# Altium schematic sheet [06] - Clock_Management.SchDoc
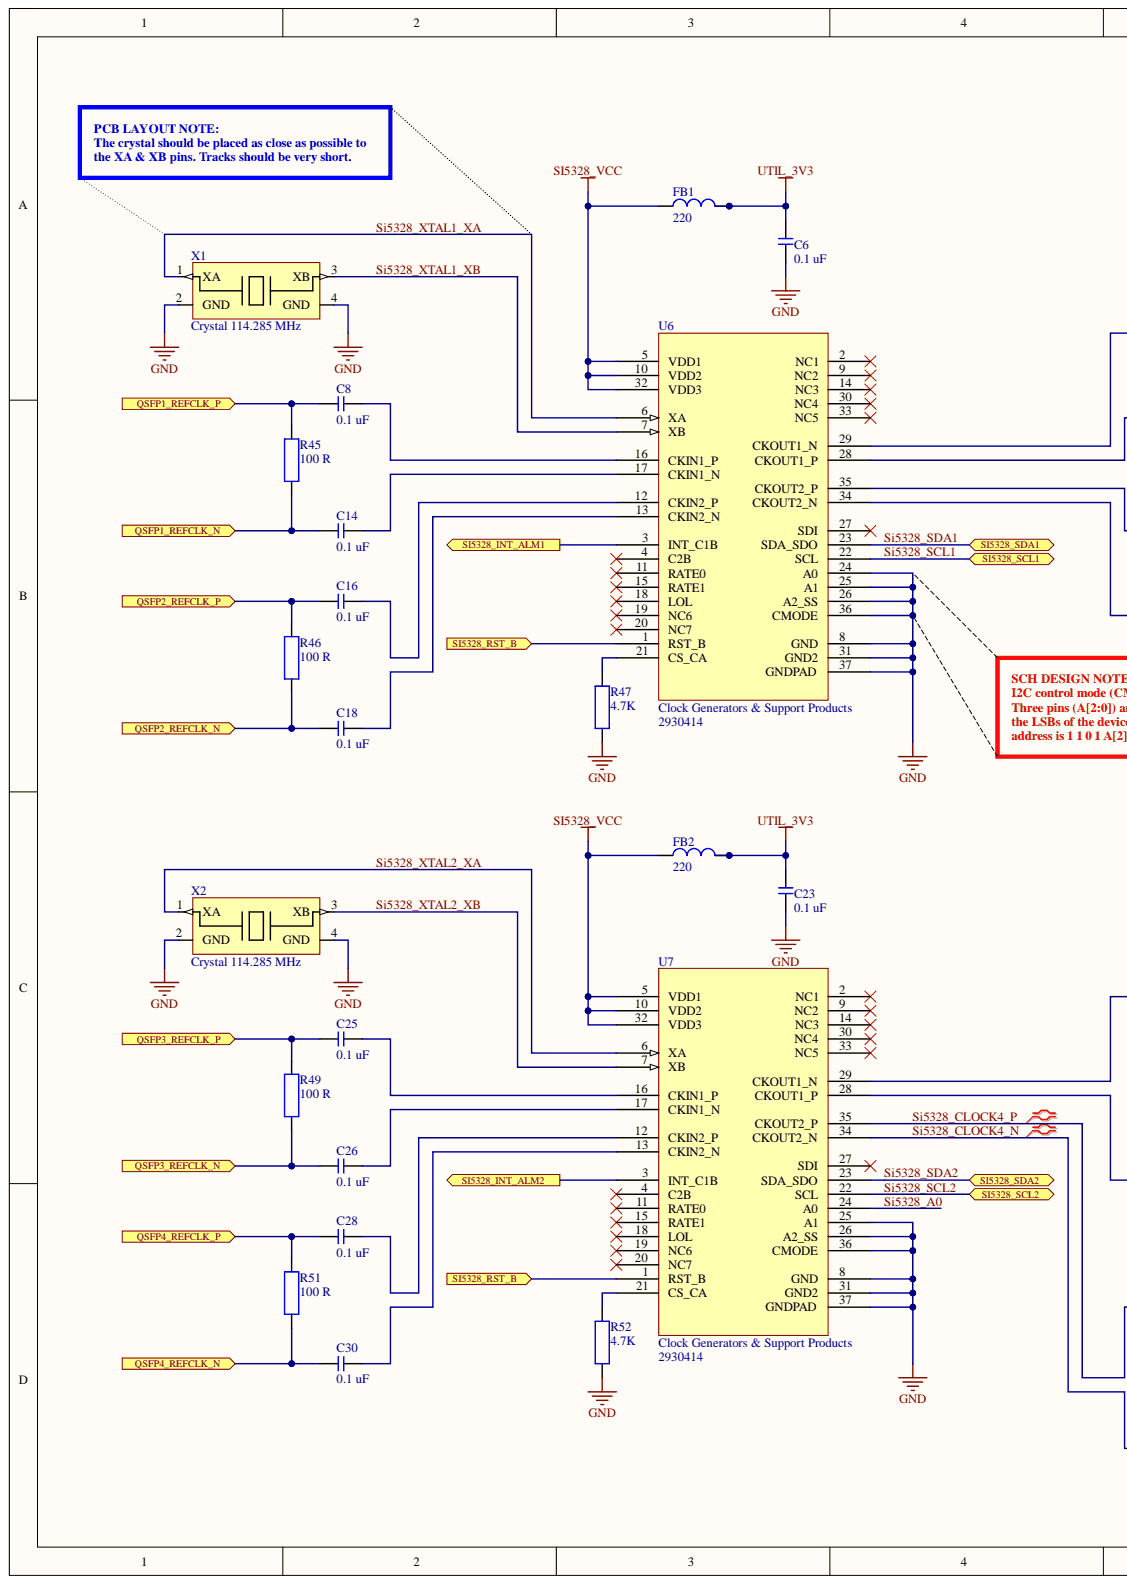
[diagram p0: [06] - Clock_Management.SchDoc, left]
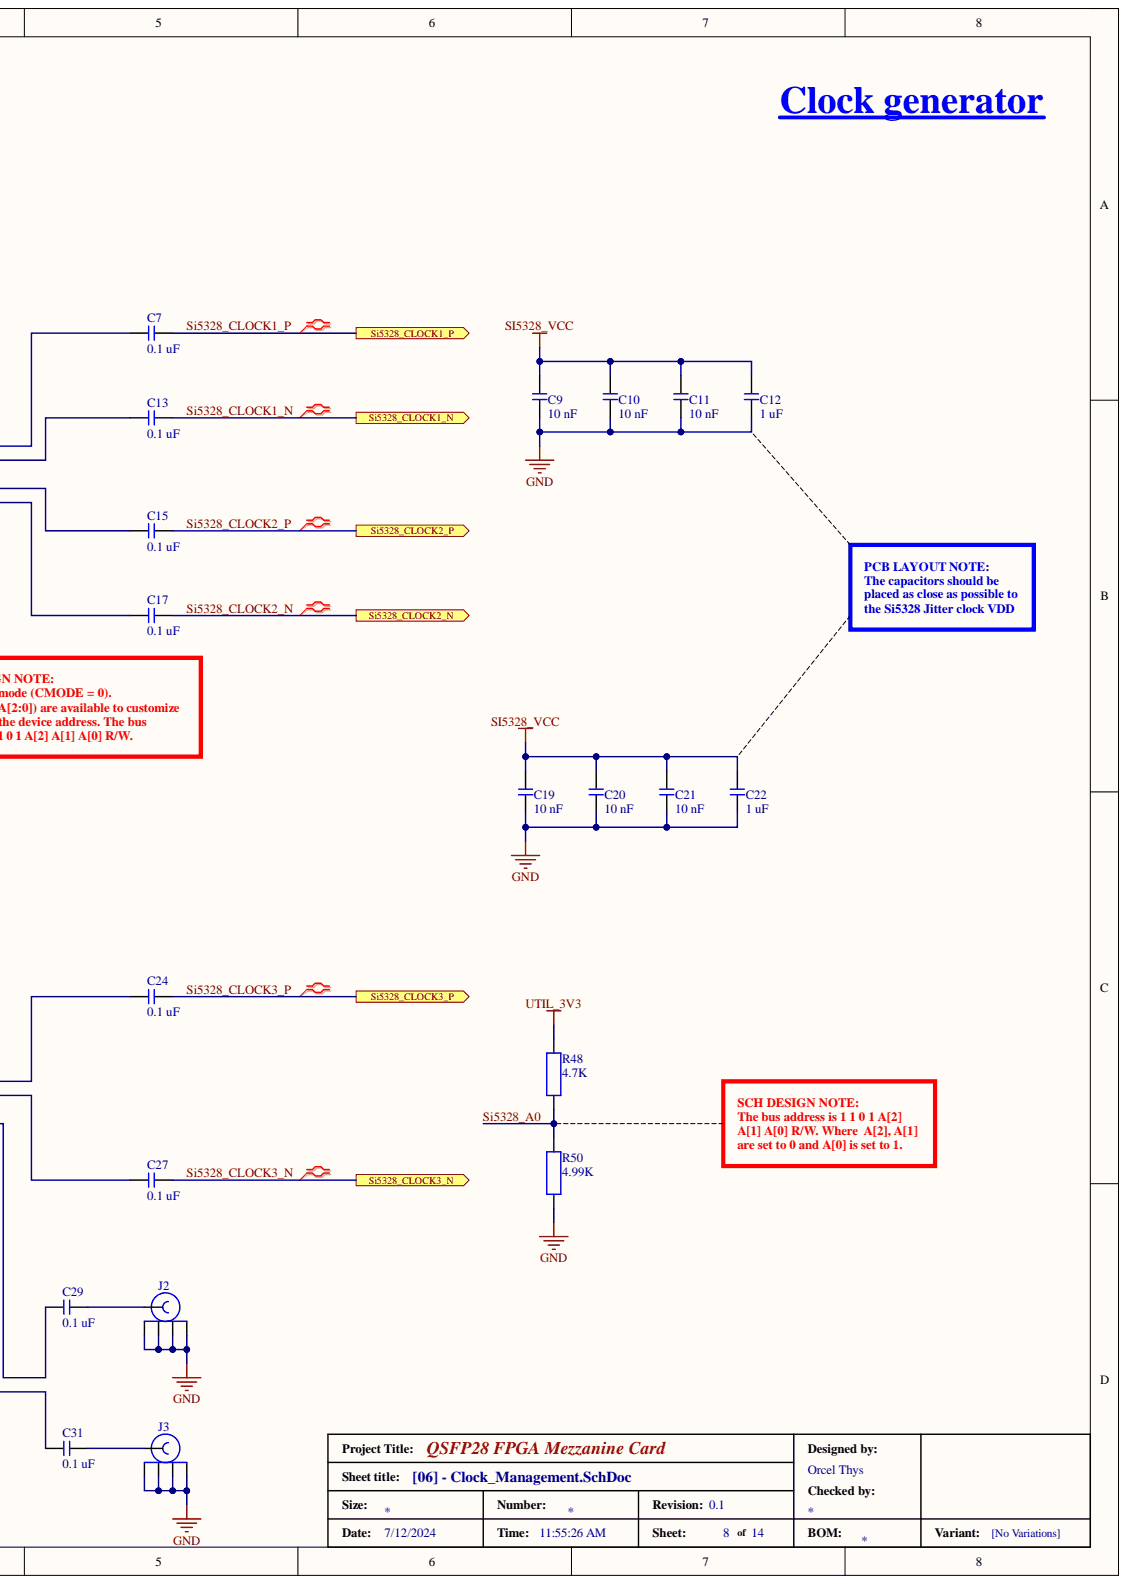
[diagram p1: [06] - Clock_Management.SchDoc, right]

## Parts
C6  0.1 uF  [C0402]
C7  0.1 uF  [C0402]
C8  0.1 uF  [C0402]
C9  10 nF  [C0402]
C10  10 nF  [C0402]
C11  10 nF  [C0402]
C12  1 uF  [C0603]
C13  0.1 uF  [C0402]
C14  0.1 uF  [C0402]
C15  0.1 uF  [C0402]
C16  0.1 uF  [C0402]
C17  0.1 uF  [C0402]
C18  0.1 uF  [C0402]
C19  10 nF  [C0402]
C20  10 nF  [C0402]
C21  10 nF  [C0402]
C22  1 uF  [C0603]
C23  0.1 uF  [C0402]
C24  0.1 uF  [C0402]
C25  0.1 uF  [C0402]
C26  0.1 uF  [C0402]
C27  0.1 uF  [C0402]
C28  0.1 uF  [C0402]
C29  0.1 uF  [C0402]
C30  0.1 uF  [C0402]
C31  0.1 uF  [C0402]
FB1  220  [BEADC1608X75N]
FB2  220  [BEADC1608X75N]
J2  SMA-J-P-H_ST-EM1  [SAMTEC_SMA-J-P-H-ST-EM1]  (value not drawn)
J3  SMA-J-P-H_ST-EM1  [SAMTEC_SMA-J-P-H-ST-EM1]  (value not drawn)
R45  100 R  [R0402]
R46  100 R  [R0402]
R47  4.7K  [R0402]
R48  4.7K  [R0402]
R49  100 R  [R0402]
R50  4.99K  [R0402]
R51  100 R  [R0402]
R52  4.7K  [R0402]
U6  Clock Generators & Support Products  Supplier Part Number 1: 2930414  [QFN_50P600X600X90-37N-D]
U7  Clock Generators & Support Products  Supplier Part Number 1: 2930414  [QFN_50P600X600X90-37N-D]
X1  Crystal 114.285 MHz  [ABM8]
X2  Crystal 114.285 MHz  [ABM8]

## Ports
QSFP1_REFCLK_N, QSFP1_REFCLK_P, QSFP2_REFCLK_N, QSFP2_REFCLK_P, QSFP3_REFCLK_N, QSFP3_REFCLK_P, QSFP4_REFCLK_N, QSFP4_REFCLK_P, Si5328_CLOCK1_N, Si5328_CLOCK1_P, Si5328_CLOCK2_N, Si5328_CLOCK2_P, Si5328_CLOCK3_N, Si5328_CLOCK3_P, SI5328_INT_ALM1, SI5328_INT_ALM2, SI5328_RST_B, SI5328_SCL1, SI5328_SCL2, SI5328_SDA1, SI5328_SDA2

## Nets
GND: C6.1 C9.1 C10.1 C11.1 C12.1 C19.1 C20.1 C21.1 C22.1 C23.1 J2.2 J2.3 J2.4 J2.5 J3.2 J3.3 J3.4 J3.5 R47.1 R50.1 R52.1 U6.8(GND) U6.24(A0) U6.25(A1) U6.26(A2_SS) U6.31(GND2) U6.36(CMODE) U6.37(GNDPAD) U7.8(GND) U7.25(A1) U7.26(A2_SS) U7.31(GND2) U7.36(CMODE) U7.37(GNDPAD) X1.2(GND) X1.4(GND) X2.2(GND) X2.4(GND)
UTIL_3V3: C6.2 C23.2 FB1.2 FB2.2 R48.2
QSFP1_REFCLK_N: C14.1 R45.1 ; port QSFP1_REFCLK_N
QSFP1_REFCLK_P: C8.1 R45.2 ; port QSFP1_REFCLK_P
QSFP2_REFCLK_N: C18.1 R46.1 ; port QSFP2_REFCLK_N
QSFP2_REFCLK_P: C16.1 R46.2 ; port QSFP2_REFCLK_P
QSFP3_REFCLK_N: C26.1 R49.1 ; port QSFP3_REFCLK_N
QSFP3_REFCLK_P: C25.1 R49.2 ; port QSFP3_REFCLK_P
QSFP4_REFCLK_N: C30.1 R51.1 ; port QSFP4_REFCLK_N
QSFP4_REFCLK_P: C28.1 R51.2 ; port QSFP4_REFCLK_P
Si5328_A0: C9.2 C10.2 C11.2 C12.2 C19.2 C20.2 C21.2 C22.2 FB1.1 FB2.1 R48.1 R50.2 U6.5(VDD1) U6.10(VDD2) U6.32(VDD3) U7.5(VDD1) U7.10(VDD2) U7.24(A0) U7.32(VDD3)
Si5328_CLOCK1_N: C13.2 ; port Si5328_CLOCK1_N
Si5328_CLOCK1_P: C7.2 ; port Si5328_CLOCK1_P
Si5328_CLOCK2_N: C17.2 ; port Si5328_CLOCK2_N
Si5328_CLOCK2_P: C15.2 ; port Si5328_CLOCK2_P
Si5328_CLOCK3_N: C27.2 ; port Si5328_CLOCK3_N
Si5328_CLOCK3_P: C24.2 ; port Si5328_CLOCK3_P
Si5328_CLOCK4_N: C31.1 U7.34(CKOUT2_N)
Si5328_CLOCK4_P: C29.1 U7.35(CKOUT2_P)
SI5328_INT_ALM1: U6.3(INT_C1B) ; port SI5328_INT_ALM1
SI5328_INT_ALM2: U7.3(INT_C1B) ; port SI5328_INT_ALM2
SI5328_RST_B: U6.1(RST_B) U7.1(RST_B) ; port SI5328_RST_B
Si5328_SCL1: U6.22(SCL) ; port SI5328_SCL1
Si5328_SCL2: U7.22(SCL) ; port SI5328_SCL2
Si5328_SDA1: U6.23(SDA_SDO) ; port SI5328_SDA1
Si5328_SDA2: U7.23(SDA_SDO) ; port SI5328_SDA2
Si5328_XTAL1_XA: U6.6(XA) X1.1(XA)
Si5328_XTAL1_XB: U6.7(XB) X1.3(XB)
Si5328_XTAL2_XA: U7.6(XA) X2.1(XA)
Si5328_XTAL2_XB: U7.7(XB) X2.3(XB)
NetC7_1: C7.1 U6.29(CKOUT1_N)
NetC8_2: C8.2 U6.16(CKIN1_P)
NetC13_1: C13.1 U6.28(CKOUT1_P)
NetC14_2: C14.2 U6.17(CKIN1_N)
NetC15_1: C15.1 U6.35(CKOUT2_P)
NetC16_2: C16.2 U6.12(CKIN2_P)
NetC17_1: C17.1 U6.34(CKOUT2_N)
NetC18_2: C18.2 U6.13(CKIN2_N)
NetC24_1: C24.1 U7.29(CKOUT1_N)
NetC25_2: C25.2 U7.16(CKIN1_P)
NetC26_2: C26.2 U7.17(CKIN1_N)
NetC27_1: C27.1 U7.28(CKOUT1_P)
NetC28_2: C28.2 U7.12(CKIN2_P)
NetC29_2: C29.2 J2.1
NetC30_2: C30.2 U7.13(CKIN2_N)
NetC31_2: C31.2 J3.1
NetR47_2: R47.2 U6.21(CS_CA)
NetR52_2: R52.2 U7.21(CS_CA)

Not connected: U6 2(NC1) 4(C2B) 9(NC2) 11(RATE0) 14(NC3) 15(RATE1) 18(LOL) 19(NC6) 20(NC7) 27(SDI) 30(NC4) 33(NC5); U7 2(NC1) 4(C2B) 9(NC2) 11(RATE0) 14(NC3) 15(RATE1) 18(LOL) 19(NC6) 20(NC7) 27(SDI) 30(NC4) 33(NC5)

## Library data of the parts
C6-C8 C13-C18 C23-C31 = UMK105B7104KV-FR: Cap 0402 0.1 uF 50 V ±10 % Tolerance X7R Surface Mount Multilayer Ceramic Capacitor; Manufacturer: Taiyo Yuden; Capacitance: 100nF; Tolerance: 10%; Voltage Rating: 50V; Case Code (Imperial): 0402; Case Code (Metric): 1005; Case/Package: 0402; Category: Ceramic Capacitors; Component Kind: Standard; ComponentLink1Description: https://datasheet.ciiva.com/24356/619umk105b7104kv-fr-24356845.pdf?src-supplier=Onlinecomponents.com; ComponentLink1URL: https://datasheet.ciiva.com/24356/619umk105b7104kv-fr-24356845.pdf?src-supplier=Onlinecomponents.com; ComponentLink2Description: https://datasheet.ciiva.com/30180/52umk105b7104kv-fr-30180098.pdf?src-supplier=Onlinecomponents.com; ComponentLink2URL: https://datasheet.ciiva.com/30180/52umk105b7104kv-fr-30180098.pdf?src-supplier=Onlinecomponents.com; ComponentLink3Description: https://datasheet.ciiva.com/18697/mlcc-all-e-18697452.pdf?src-supplier=Digi-Key; ComponentLink3URL: https://datasheet.ciiva.com/18697/mlcc-all-e-18697452.pdf?src-supplier=Digi-Key; ComponentLink4Description: https://datasheet.ciiva.com/18697/mlcc-all-e-18697451.pdf?src-supplier=Digi-Key; ComponentLink4URL: https://datasheet.ciiva.com/18697/mlcc-all-e-18697451.pdf?src-supplier=Digi-Key; ComponentLink5Description: https://datasheet.ciiva.com/18697/mlcc-all-e-18697453.pdf?src-supplier=Digi-Key; ComponentLink5URL: https://datasheet.ciiva.com/18697/mlcc-all-e-18697453.pdf?src-supplier=Digi-Key; Dielectric: X7R; Halogen Free: Halogen Free; Height: 0.5mm; Lead Free: Lead Free; Length: 0.039inch; Manufacturer Part Number: UMK105B7104KV-FR; Max Operating Temperature: 125°C; Min Operating Temperature: -55°C; Mount: Surface Mount; Package Quantity: 1; Packaging: Tape and Reel; Pricing 1: 10000=0.016 (EUR); RoHS: Yes; RoHS Compliant: Yes; Series: M; Stock 1: 60000; Supplier 1: Rs; Supplier Part Number 1: 1782869; Termination: SMD/SMT; Thickness: 0.022inch; Voltage Rating (DC): 50V; Width: 0.02inch; Library Name: QSFP28_FMC_lib.SchLib
C9-C11 C19-C21 = C0402C103K3RACTU: Cap Multilayer Ceramic Capacitors MLCC - SMD/SMT 25volts 10000pF X7R 10%; Manufacturer: KEMET; Capacitance: 10nF; Dissipation Factor: 3.5%; Voltage Rating: 25V; Case Code (Imperial): 0402; Case Code (Metric): 1005; Case/Package: 0402; Category: Ceramic Capacitors; Component Kind: Standard; ComponentLink1Description: https://datasheet.ciiva.com/7747/kem-c1002-x7r-smd-7747612.pdf?src-supplier=Element14; ComponentLink1URL: https://datasheet.ciiva.com/7747/kem-c1002-x7r-smd-7747612.pdf?src-supplier=Element14; ComponentLink2Description: https://datasheet.ciiva.com/45846/docfinderpartnumberc0402c102k5ractu-45846917.pdf?src-supplier=Onlinecomponents.com; ComponentLink2URL: https://datasheet.ciiva.com/45846/docfinderpartnumberc0402c102k5ractu-45846917.pdf?src-supplier=Onlinecomponents.com; ComponentLink3Description: https://datasheet.ciiva.com/18192/getdatasheetpartid-330802-18192396.pdf?src-supplier=Verical; ComponentLink3URL: https://datasheet.ciiva.com/18192/getdatasheetpartid-330802-18192396.pdf?src-supplier=Verical; Construction: Flat; Depth: 0.5mm; Dielectric: X7R; Height: 0.5mm; Lead Pitch: 0.3mm; Length: 1mm; Manufacturer Part Number: C0402C103K3RACTU; Max Operating Temperature: 125°C; Min Operating Temperature: -55°C; Mount: Surface Mount; Number of Pins: 2; Package Quantity: 10000; Packaging: Tape and Reel; Pricing 1: 1=0.1, 10=0.018, 50=0.018, 100=0.008, 1000=0.006, 10000=0.003 (USD); Radiation Hardening: No; Reach SVHC Compliant: No; RoHS: Yes; RoHS Compliant: Yes; Series: SMD Comm X7R; Stock 1: 1004059; Supplier 1: Mouser; Supplier Part Number 1: 80-C0402C103K3R; Termination: SMD/SMT; Tolerance: 10%; Voltage Rating (DC): 25V; Width: 0.5mm; Library Name: QSFP28_FMC_lib.SchLib
C12 C22 = C0603C105K3RACTU: Cap Ceramic 1uF 25V X7R 10% Pad SMD 0603 125°C T/R; Manufacturer: KEMET; Dissipation Factor: 5%; Capacitance: 1uF; Case Code (Imperial): 0603; Case Code (Metric): 1608; Case/Package: 0603; Category: Ceramic Capacitors; Component Kind: Standard; ComponentLink1Description: https://datasheet.ciiva.com/7823/1851736-7823596.pdf?src-supplier=Element14; ComponentLink1URL: https://datasheet.ciiva.com/7823/1851736-7823596.pdf?src-supplier=Element14; Construction: Flat; Depth: 0.8mm; Dielectric: X7R; Dielectric Material: Ceramic; Height: 0.8mm; Length: 1.6mm; Manufacturer Part Number: C0603C105K3RACTU; Max Operating Temperature: 125°C; Min Operating Temperature: -55°C; Mount: Surface Mount; Package Quantity: 4000; Packaging: Tape and Reel; Pricing 1: 1=0.29, 10=0.172, 50=0.172, 100=0.095, 1000=0.068, 10000=0.053 (USD); Reach SVHC Compliant: No; RoHS: Yes; RoHS Compliant: Yes; Series: SMD Comm X7R; Stock 1: 684247; Supplier 1: Mouser; Supplier Part Number 1: 80-C0603C105K3R; Termination: SMD/SMT; Tolerance: 10%; Voltage: 25V; Voltage Rating: 25V; Voltage Rating (DC): 25V; Width: 0.8mm; Library Name: QSFP28_FMC_lib.SchLib
FB1 FB2 = MPZ1608S221ATA00: 0603 220Ω ±25% DCR=0.050Ω 2.2A; Manufacturer: TDK; Core Material: Ferrite; DC Resistance (DCR): 50mR; Impedance: 220R; Number of Lines: 1; Case Code (Imperial): 0603; Case Code (Metric): 1608; Case/Package: 0603; Category: Ferrite Beads and Chips; Component Kind: Standard; ComponentLink1Description: https://datasheet.ciiva.com/8077/55167-8077073.pdf?src-supplier=Element14; ComponentLink1URL: https://datasheet.ciiva.com/8077/55167-8077073.pdf?src-supplier=Element14; ComponentLink2Description: https://datasheet.ciiva.com/8077/55167-8077071.pdf?src-supplier=Element14; ComponentLink2URL: https://datasheet.ciiva.com/8077/55167-8077071.pdf?src-supplier=Element14; ComponentLink3Description: https://datasheet.ciiva.com/46381/1486324-46381628.pdf?src-supplier=Element14; ComponentLink3URL: https://datasheet.ciiva.com/46381/1486324-46381628.pdf?src-supplier=Element14; ComponentLink4Description: https://datasheet.ciiva.com/46366/print-detail-pdf-phplangenpart-nompz1608s221ata00nreimp-unit-xmhz-46366044.pdf?src-supplier=Onlinecomponents.com; ComponentLink4URL: https://datasheet.ciiva.com/46366/print-detail-pdf-phplangenpart-nompz1608s221ata00nreimp-unit-xmhz-46366044.pdf?src-supplier=Onlinecomponents.com; Current Rating: 2.2A; Height: 0.037inch; Lead Free: Lead Free; Length: 0.063inch; Manufacturer Part Number: MPZ1608S221ATA00; Max Current Rating: 2.2A; Max DC Current: 2.2A; Mount: Surface Mount; Number of Pins: 2; Package Quantity: 1; Packaging: Cut Tape; Pricing 1: 4000=0.0235, 20000=0.023, 40000=0.0226 (EUR); Reach SVHC Compliant: No; RoHS: Yes; RoHS Compliant: Yes; Series: MPZ; Stock 1: 256000; Supplier 1: Farnell; Supplier Part Number 1: 2800773; Tolerance: 25%; Width: 0.031inch; Library Name: QSFP28_FMC_lib.SchLib
J2 J3 = SMA-J-P-H-ST-EM1: RF Connectors / Coaxial Connectors 6 GHz, 50 Ohm SMA Jack or Plug, Cable Connector; Manufacturer: Samtec; Body Material: Brass; Body Plating: Gold; Dielectric Material: PTFE; Frequency: 20GHz; Impedance: 50R; Number of Contacts: 1NUMBER; Number of Ports: 1; Voltage Rating: 335V; Case/Package: SMA; Category: RF / Coaxial Connectors; Center Contact Plating: Gold; Component Kind: Standard; ComponentLink1Description: https://datasheet.ciiva.com/646/sma-j-p-x-st-em1-mkt-646053.pdf?src-supplier=Digikey; ComponentLink1URL: https://datasheet.ciiva.com/646/sma-j-p-x-st-em1-mkt-646053.pdf?src-supplier=Digikey; Connector Type: SMA; Contact Material: Copper; Contact Plating: Gold; Depth: 13.33mm; Fastening Type: Threaded; Housing Color: Gold; Insulation Material: Polytetrafluoroethylene; Length: 6.35mm; Manufacturer Part Number: SMA-J-P-H-ST-EM1; Max Frequency: 20GHz; Max Operating Temperature: 125°C; Min Operating Temperature: -65°C; Mount: Edge,Board; Orientation: Straight; Package Quantity: 1; Packaging: Tray; Pricing 1: 1=5.76, 10=4.18, 50=3.98, 100=3.83, 500=2.76 (EUR); Radiation Hardening: No; RoHS: Yes; RoHS Compliant: Yes; Series: -; Stock 1: 33; Supplier 1: Rs; Supplier Part Number 1: 1592304; Termination: Solder; Library Name: QSFP28_FMC_lib.SchLib
R45 R46 R49 R51 = ERJ-2RKF1000X: RES Thick Film 0402 100 Ohm 1% 1/10W 100ppm/C Molded SMD Punched Carrier T/R; Manufacturer: Panasonic; Max Power Dissipation: 100mW; Resistance: 100R; Temperature Coefficient: 100ppm/°C; Voltage Rating: 50V; Case Code (Imperial): 0402; Case Code (Metric): 1005; Case/Package: 0402; Category: Chip SMD Resistors; Component Kind: Standard; ComponentLink1Description: https://datasheet.ciiva.com/27056/2007311-27056035.pdf?src-supplier=Jameco; ComponentLink1URL: https://datasheet.ciiva.com/27056/2007311-27056035.pdf?src-supplier=Jameco; ComponentLink2Description: https://datasheet.ciiva.com/11821/0900766b80f80784-11821173.pdf?src-supplier=Rs; ComponentLink2URL: https://datasheet.ciiva.com/11821/0900766b80f80784-11821173.pdf?src-supplier=Rs; ComponentLink3Description: https://datasheet.ciiva.com/7830/426256-7830973.pdf?src-supplier=Element14; ComponentLink3URL: https://datasheet.ciiva.com/7830/426256-7830973.pdf?src-supplier=Element14; ComponentLink4Description: https://datasheet.ciiva.com/43467/1678782-43467223.pdf?src-supplier=Farnell; ComponentLink4URL: https://datasheet.ciiva.com/43467/1678782-43467223.pdf?src-supplier=Farnell; ComponentLink5Description: https://datasheet.ciiva.com/28514/1678782-28514175.pdf?src-supplier=Element14; ComponentLink5URL: https://datasheet.ciiva.com/28514/1678782-28514175.pdf?src-supplier=Element14; ComponentLink6Description: https://datasheet.ciiva.com/46372/1678782-46372741.pdf?src-supplier=Element14; ComponentLink6URL: https://datasheet.ciiva.com/46372/1678782-46372741.pdf?src-supplier=Element14; ComponentLink7Description: https://datasheet.ciiva.com/16776/70260748-16776427.pdf?src-supplier=Allied+Electronics; ComponentLink7URL: https://datasheet.ciiva.com/16776/70260748-16776427.pdf?src-supplier=Allied+Electronics; ComponentLink8Description: https://datasheet.ciiva.com/9186/aoa0000ce2-9186683.pdf?src-supplier=Avnet; ComponentLink8URL: https://datasheet.ciiva.com/9186/aoa0000ce2-9186683.pdf?src-supplier=Avnet; ComponentLink9Description: https://datasheet.ciiva.com/16199/aoa0000ce2-16199575.pdf?src-supplier=Future+Electronics; ComponentLink9URL: https://datasheet.ciiva.com/16199/aoa0000ce2-16199575.pdf?src-supplier=Future+Electronics; ComponentLink10Description: https://datasheet.ciiva.com/48531/aoa0000ce2-48531067.pdf?src-supplier=Avnet; ComponentLink10URL: https://datasheet.ciiva.com/48531/aoa0000ce2-48531067.pdf?src-supplier=Avnet; ComponentLink11Description: https://datasheet.ciiva.com/30260/aoa0000ce2-30260809.pdf?src-supplier=Onlinecomponents.com; ComponentLink11URL: https://datasheet.ciiva.com/30260/aoa0000ce2-30260809.pdf?src-supplier=Onlinecomponents.com; Construction: Rectangular; Contact Plating: Tin; Depth: 0.5mm; Features: Automotive AEC-Q200; Height: 0.35mm; Lead Free: Lead Free; Length: 1mm; Manufacturer Part Number: ERJ-2RKF1000X; Max Operating Temperature: 155°C; Military Standard: Not; Min Operating Temperature: -55°C; Mount: Surface Mount; Number of Pins: 2; Number of Terminations: 2; Package Quantity: 1; Packaging: Tape and Reel; Power Rating: 100mW; Pricing 1: 1=0.1, 10=0.017, 50=0.017, 100=0.008, 1000=0.006, 10000=0.004 (USD); Radiation Hardening: No; Reach SVHC Compliant: No; Resistance Tolerance: 1%; Resistor Type: Thick Film; RoHS: Yes; RoHS Compliant: Yes; Series: ERJ; Size Code: 0402; Stock 1: 11989335; Supplier 1: Mouser; Supplier Part Number 1: 667-ERJ-2RKF1000X; Technology: THICK FILM; Termination: SMD/SMT; Tolerance: 1%; Voltage Rating (DC): 50V; Width: 0.5mm; Library Name: QSFP28_FMC_lib.SchLib
R47 R48 R52 = ERJ-2RKF4701X: RES Thick Film 0402 4.7K Ohm 1% 1/10W ±100ppm/°C Molded SMD Punched Carrier T/R; Manufacturer: Panasonic; Power Rating: 100mW; Resistance: 4.7kR; Voltage Rating: 50V; Case Code (Imperial): 0402; Case Code (Metric): 1005; Category: Chip SMD Resistors; Component Kind: Standard; ComponentLink1Description: https://datasheet.ciiva.com/11821/0900766b80f80784-11821329.pdf?src-supplier=Rs; ComponentLink1URL: https://datasheet.ciiva.com/11821/0900766b80f80784-11821329.pdf?src-supplier=Rs; ComponentLink2Description: https://datasheet.ciiva.com/7830/aoa0000ce2-7830727.pdf?src-supplier=Element14; ComponentLink2URL: https://datasheet.ciiva.com/7830/aoa0000ce2-7830727.pdf?src-supplier=Element14; ComponentLink3Description: https://datasheet.ciiva.com/22781/1678782-22781576.pdf?src-supplier=Farnell; ComponentLink3URL: https://datasheet.ciiva.com/22781/1678782-22781576.pdf?src-supplier=Farnell; ComponentLink4Description: https://datasheet.ciiva.com/22667/1678782-22667886.pdf?src-supplier=Element14; ComponentLink4URL: https://datasheet.ciiva.com/22667/1678782-22667886.pdf?src-supplier=Element14; ComponentLink5Description: https://datasheet.ciiva.com/22723/1678782-22723903.pdf?src-supplier=Element14; ComponentLink5URL: https://datasheet.ciiva.com/22723/1678782-22723903.pdf?src-supplier=Element14; ComponentLink6Description: https://datasheet.ciiva.com/24025/aoa0000ce2-24025663.pdf?src-supplier=Mouser; ComponentLink6URL: https://datasheet.ciiva.com/24025/aoa0000ce2-24025663.pdf?src-supplier=Mouser; ComponentLink7Description: https://datasheet.ciiva.com/16763/70260748-16763151.pdf?src-supplier=Allied+Electronics; ComponentLink7URL: https://datasheet.ciiva.com/16763/70260748-16763151.pdf?src-supplier=Allied+Electronics; ComponentLink8Description: https://datasheet.ciiva.com/9195/aoa0000ce2-9195737.pdf?src-supplier=Avnet; ComponentLink8URL: https://datasheet.ciiva.com/9195/aoa0000ce2-9195737.pdf?src-supplier=Avnet; ComponentLink9Description: https://datasheet.ciiva.com/30053/aoa0000ce2-30053990.pdf?src-supplier=Onlinecomponents.com; ComponentLink9URL: https://datasheet.ciiva.com/30053/aoa0000ce2-30053990.pdf?src-supplier=Onlinecomponents.com; Height: 0.35mm; Lead Free: Lead Free; Length: 1mm; Manufacturer Part Number: ERJ-2RKF4701X; Max Operating Temperature: 155°C; Min Operating Temperature: -55°C; Package Quantity: 10000; Packaging: Cut Tape; Pricing 1: 1=0.1, 10=0.018, 50=0.018, 100=0.008, 1000=0.006, 10000=0.003 (USD); RoHS: Yes; RoHS Compliant: Yes; Series: ERJ-2Rx; Stock 1: 4815685; Supplier 1: Mouser; Supplier Part Number 1: 667-ERJ-2RKF4701X; Technology: THICK FILM; Temperature Coefficient: 100ppm/°C; Termination: SMD/SMT; Tolerance: 1%; Voltage Rating (DC): 50V; Weight: 0.000388oz; Width: 0.5mm; Library Name: QSFP28_FMC_lib.SchLib
R50 = ERJ-2RKF4991X: RES SMD 4.99K OHM 1% 1/10W 0402; Manufacturer: Panasonic; Max Power Dissipation: 100mW; Resistance: 4.99kR; Temperature Coefficient: 100ppm/°C; Voltage Rating: 50V; Case Code (Imperial): 0402; Case Code (Metric): 1005; Case/Package: 0402; Category: Chip SMD Resistors; Component Kind: Standard; ComponentLink1Description: https://datasheet.ciiva.com/46388/426256-46388311.pdf?src-supplier=Element14; ComponentLink1URL: https://datasheet.ciiva.com/46388/426256-46388311.pdf?src-supplier=Element14; ComponentLink2Description: https://datasheet.ciiva.com/46376/426256-46376955.pdf?src-supplier=Element14; ComponentLink2URL: https://datasheet.ciiva.com/46376/426256-46376955.pdf?src-supplier=Element14; ComponentLink3Description: https://datasheet.ciiva.com/22749/1678782-22749654.pdf?src-supplier=Element14; ComponentLink3URL: https://datasheet.ciiva.com/22749/1678782-22749654.pdf?src-supplier=Element14; ComponentLink4Description: https://datasheet.ciiva.com/24158/aoa0000ce2-24158871.pdf?src-supplier=Mouser; ComponentLink4URL: https://datasheet.ciiva.com/24158/aoa0000ce2-24158871.pdf?src-supplier=Mouser; ComponentLink5Description: https://datasheet.ciiva.com/16775/70260748-16775018.pdf?src-supplier=Allied+Electronics; ComponentLink5URL: https://datasheet.ciiva.com/16775/70260748-16775018.pdf?src-supplier=Allied+Electronics; ComponentLink6Description: https://datasheet.ciiva.com/16773/70260748-16773110.pdf?src-supplier=Allied+Electronics; ComponentLink6URL: https://datasheet.ciiva.com/16773/70260748-16773110.pdf?src-supplier=Allied+Electronics; ComponentLink7Description: https://datasheet.ciiva.com/16199/aoa0000ce2-16199546.pdf?src-supplier=Future+Electronics; ComponentLink7URL: https://datasheet.ciiva.com/16199/aoa0000ce2-16199546.pdf?src-supplier=Future+Electronics; Construction: Rectangular; Contact Plating: Tin; Depth: 0.5mm; Features: Automotive AEC-Q200; Height: 0.016inch; Lead Free: Lead Free; Length: 1mm; Manufacturer Part Number: ERJ-2RKF4991X; Max Operating Temperature: 155°C; Military Standard: Not; Min Operating Temperature: -55°C; Mount: Surface Mount; Number of Terminations: 2; Package Quantity: 1; Packaging: Tape and Reel; Power Rating: 100mW; Pricing 1: 1=0.1, 10=0.018, 50=0.018, 100=0.008, 1000=0.006, 10000=0.004 (USD); Radiation Hardening: No; Reach SVHC Compliant: No; Resistance Tolerance: 1%; Resistor Type: Thick Film; RoHS: Yes; RoHS Compliant: Yes; Series: ERJ; Stock 1: 1218727; Supplier 1: Mouser; Supplier Part Number 1: 667-ERJ-2RKF4991X; Technology: THICK FILM; Termination: SMD/SMT; Tolerance: 1%; Voltage Rating (DC): 50V; Weight: 2.8E-05oz; Width: 0.5mm; Working Voltage: 50V; Library Name: QSFP28_FMC_lib.SchLib
U6 U7 = SI5328B-C-GM: Synchronous Ethernet Jitter Attenuating Clock Multiplier Single 0.008 MHz to 710MHz 36-Pin QFN; Manufacturer: Silicon Labs; Category: Clock and Timing; Component Kind: Standard; ComponentLink1Description: https://datasheet.ciiva.com/29898/si5328-29898491.pdf?src-supplier=Avnet; ComponentLink1URL: https://datasheet.ciiva.com/29898/si5328-29898491.pdf?src-supplier=Avnet; Manufacturer Part Number: SI5328B-C-GM; Pricing 1: 1=16.74, 10=16.37, 25=16, 50=15.63, 100=15.32 (EUR); RoHS: Yes; Supplier 1: Farnell; Library Name: QSFP28_FMC_lib.SchLib
X1 X2 = ABM8-166-114.285MHZ-T2: ABM8 Series 114.285 MHz ±20ppm 18pF -40 to +85 °C Ultra Miniature Ceramic Crysta; Manufacturer: Abracon; ESR (Equivalent Series Resistance): 80R; Case/Package: SMD/SMT; Category: Crystals; Component Kind: Standard; ComponentLink1Description: https://datasheet.ciiva.com/29632/abm8-166-29632188.pdf?src-supplier=Digi-Key; ComponentLink1URL: https://datasheet.ciiva.com/29632/abm8-166-29632188.pdf?src-supplier=Digi-Key; ComponentLink2Description: https://datasheet.ciiva.com/29632/abm8-166-29632187.pdf?src-supplier=Digi-Key; ComponentLink2URL: https://datasheet.ciiva.com/29632/abm8-166-29632187.pdf?src-supplier=Digi-Key; ComponentLink3Description: https://datasheet.ciiva.com/29632/abm8-166-29632189.pdf?src-supplier=Digi-Key; ComponentLink3URL: https://datasheet.ciiva.com/29632/abm8-166-29632189.pdf?src-supplier=Digi-Key; Frequency: 114.285MHz; Frequency Stability: 20ppm; Frequency Tolerance: 20ppm; Lead Free: Lead Free; Load Capacitance: 18pF; Manufacturer Part Number: ABM8-166-114.285MHZ-T2; Max Operating Temperature: 85°C; Min Operating Temperature: -40°C; Mount: Surface Mount; Number of Pins: 4; Packaging: Cut Tape; Pricing 1: 2=1.675, 50=3.52, 100=3.075, 250=3.04, 500=2.98 (EUR); RoHS: Yes; RoHS Compliant: Yes; Series: ABM8; Series Resistance: 80R; Stock 1: 3926; Supplier 1: Rs; Supplier Part Number 1: 1712823; Library Name: FmcLib.SchLib
